# Altium schematic sheet pont en h.SchDoc("pont en h")
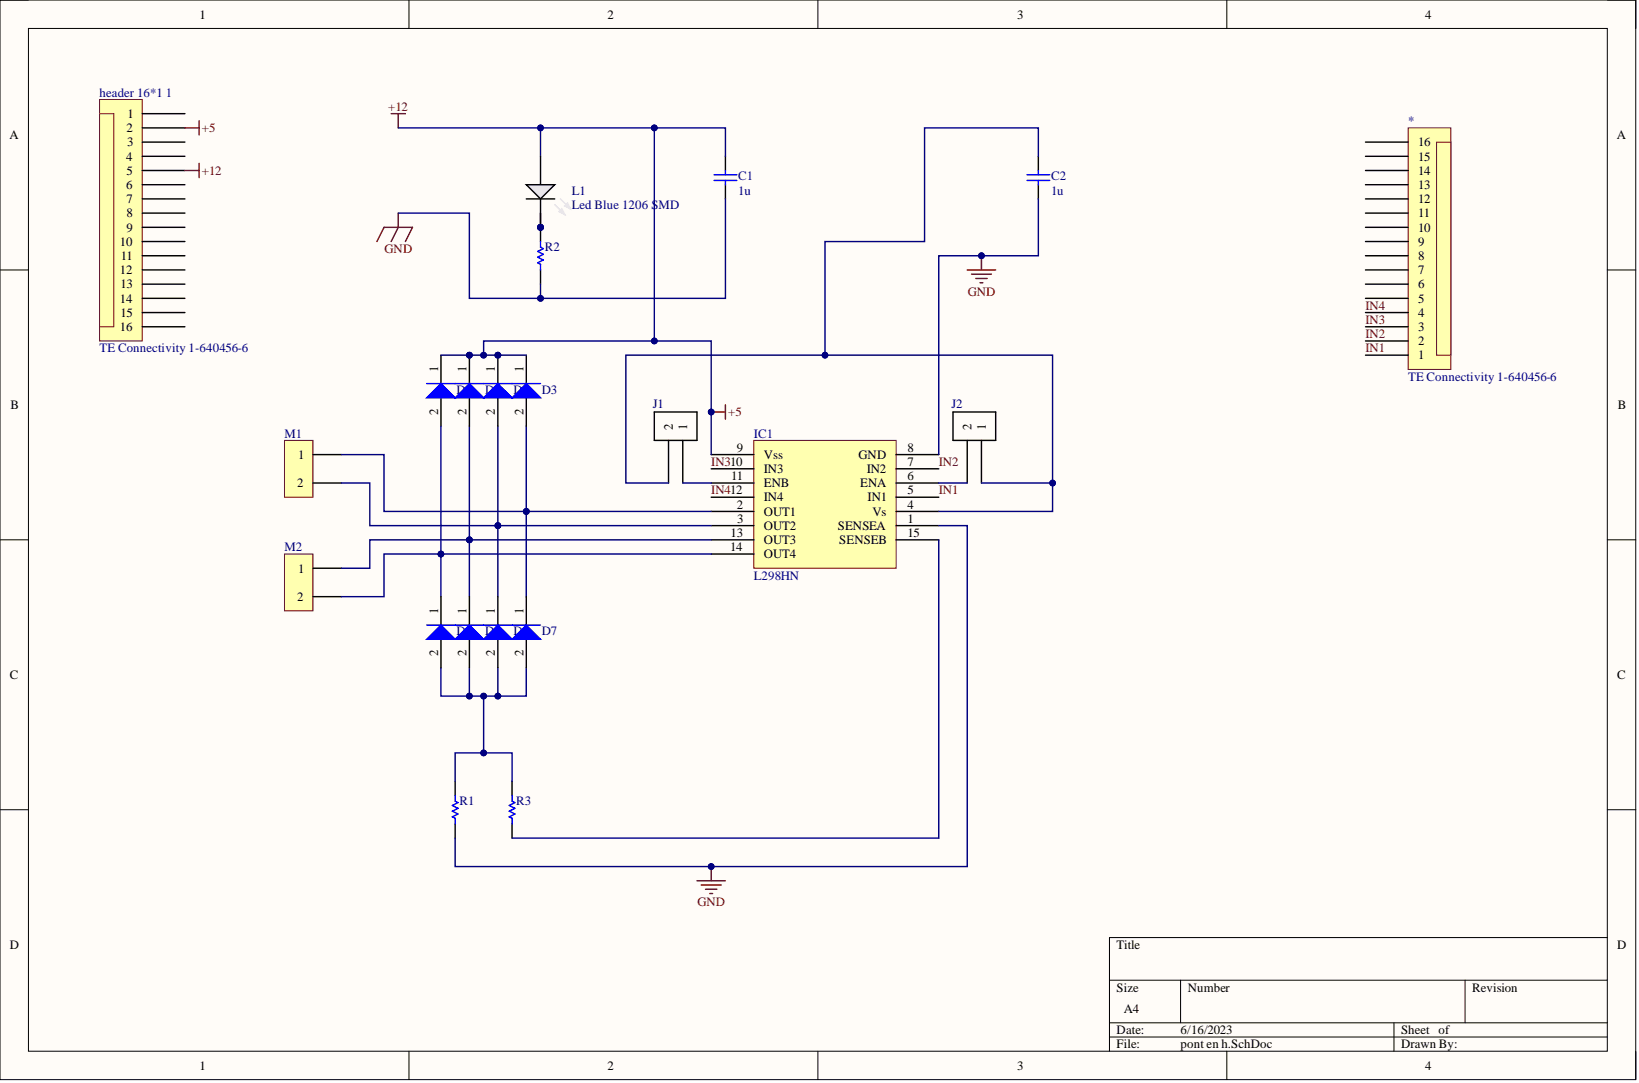
[diagram p0: pont en h.SchDoc]

## Parts
*  TE Connectivity 1-640456-6  [PCB-345877-1]
C1  1u
C2  1u
D1  (no value)  [PCB-93eesnz9fqft5tg3zumo-1]
D2  (no value)  [PCB-93eesnz9fqft5tg3zumo-1]
D3  (no value)  [PCB-93eesnz9fqft5tg3zumo-1]
D4  (no value)  [PCB-93eesnz9fqft5tg3zumo-1]
D5  (no value)  [PCB-93eesnz9fqft5tg3zumo-1]
D6  (no value)  [PCB-93eesnz9fqft5tg3zumo-1]
D7  (no value)  [PCB-93eesnz9fqft5tg3zumo-1]
D9  (no value)  [PCB-93eesnz9fqft5tg3zumo-1]
header_16*1_1  TE Connectivity 1-640456-6  Manufacturer Part Number: 1-640456-6  [PCB-345877-1]
IC1  L298HN
J1  (no value)  [PCB-psmpzu51py3p54h2h388-1]
J2  (no value)  [PCB-psmpzu51py3p54h2h388-1]
L1  Led Blue 1206 SMD  [PCB-dyudieb3kox3j5j1lvp2-1]
M1  (no value)  [PCB-aquf9io8cfjuczgbngpx-1]
M2  (no value)  [PCB-aquf9io8cfjuczgbngpx-1]
R1  (no value)
R2  (no value)
R3  (no value)

## Nets
+5: C1.2 D1.1 D2.1 D3.1 D9.1 header_16*1_1.2 header_16*1_1.5 IC1.9(Vss) L1.1
GND: C1.1 C2.1 IC1.1(SENSEA) IC1.8(GND) R1.1 R2.1
IN1: *.1 IC1.5(IN1)
IN2: *.2 IC1.7(IN2)
IN3: *.3 IC1.10(IN3)
IN4: *.4 IC1.12(IN4)
NetC2_2: C2.2 IC1.4(Vs) J1.2 J2.1
NetD1_2: D1.2 D5.1 IC1.13(OUT3) M2.1
NetD2_2: D2.2 D6.1 IC1.3(OUT2) M1.2
NetD3_2: D3.2 D7.1 IC1.2(OUT1) M1.1
NetD4_1: D4.1 D9.2 IC1.14(OUT4) M2.2
NetD4_2: D4.2 D5.2 D6.2 D7.2 R1.2 R3.2
NetIC1_6: IC1.6(ENA) J2.2
NetIC1_11: IC1.11(ENB) J1.1
NetIC1_15: IC1.15(SENSEB) R3.1
NetL1_2: L1.2 R2.2

Not connected: * 5 6 7 8 9 10 11 12 13 14 15 16; header_16*1_1 1 3 4 6 7 8 9 10 11 12 13 14 15 16

## Library data of the parts
* header_16*1_1 = CMP-5cb5977adcc98372-4: Header, Friction Lock; MTA-100; 16; 250 VAC; Polyester; Tin; Copper Alloy; Tin; ELV Compliant: Compliant; Gender: Male; Lead-Free Status: Lead Free; Mounting Angle: 180 °; Number of Contacts: 16; Pin Pitch: 2.54 mm; Shrouded: No; Voltage Rating (AC): 250 V; Color: White; Component Kind: Standard; Contact Material: Copper Alloy; Contact Plating: Tin; Flammability Rating: UL 94 V-0; Housing Color: White; Housing Material: Polyester; Library Name: My Personal Space; Lifecycle Status: Active; Mounting Style: Through Hole, Vertical; Number of Positions: 16; Number of Rows: 1; Octopart URL: https://octopart.com/1-640456-6-te+connectivity-46507290; OctopartID: 5cb5977adcc98372; Operating Temperature: 105 °C (max); Orientation: Vertical; Packaging: Bag; REACH SVHC Compliance: No SVHC; RoHS: Compliant; Size-Height: 7.87 mm; Size-Length: 40.6 mm; Size-Width: 5.71 mm; Voltage Rating (DC): 250 V
  *: Manufacturer Part Number: 1-640456-6
C1 C2 = CMP-8752282-2: Cap Ceramic 470pF 50V C0G 5% SMD 1206 125C Plastic T/R; Manufacturer Part Number: C1206C471J5GACTU; Capacitance: 4.7e-10 F; Lead-Free Status: Lead Free; Voltage Rating (DC): 50.0 V; Capacitance Tolerance: ±5%; Case/Package: 1206; Component Kind: Standard; Dielectric Material: Ceramic; Library Name: My Personal Space; Mounting Style: Surface Mount; Octopart Url: https://octopart.com/c1206c471j5gac7800-kemet-3915253; OctopartID: 8a66435712f555a1; RoHS: Compliant
D1-D7 D9 = CMP-1021910-11: Diode Small Signal Switching 100V 0.15A 2-Pin Case 1206(3216Metric) T/R; Manufacturer Part Number: CD1206-S01575; Case/Package (SI): 3216; Forward Voltage: 1.00 V; Case/Package: 1206; Component Kind: Standard; Library Name: My Personal Space; Mounting Style: Surface Mount; Number of Pins: 2; Octopart URL: https://octopart.com/cd1206-s01575-bourns-709948; OctopartID: d7cc1ecc2796080b; Operating Temperature: -55.0 °C to 125 °C; Packaging: Reel; REACH SVHC Compliance: No SVHC; RoHS: Compliant
IC1 = CMP-7f8fb6854c1aa048-2: PMIC - gate/half-bridge gate drivers STMicroelectronics L298HN Inductive Bipolar Multiwatt 15; Component Kind: Standard; Library Name: My Personal Space
J1 J2 = CMP-2811e342b2f0f7d6-11: AMPMODU Mod II Series, 2.54mm Pitch 2Way1 Row Straight PCB Header, Solder Term, 3A; Manufacturer Part Number: 87224-2; ELV Compliant: Compliant; Gender: Male; Lead-Free Status: Lead Free; Mounting Angle: 180 °; Number of Contacts: 2; Color: Black; Component Kind: Standard; Contact Material: Phosphor Bronze; Contact Plating: Gold, Gold Flash over Nickel; Flammability Rating: UL 94 V-0; Housing Color: Black; Housing Material: Thermoplastic; Library Name: My Personal Space; Lifecycle Status: Active; Mounting Style: PCB; Number of Positions: 2; Number of Rows: 1; Octopart URL: http://octopart.com/87224-2-te+connectivity+%2F+amp-39436167; OctopartID: 2811e342b2f0f7d6; Orientation: Vertical; Packaging: Bulk; Pin Pitch: 2.54 mm; RoHS: Compliant; Size-Length: 4.67 mm
L1 = CMP-0283cbd1fd8add44-10: High CRI LED; Color: 2700K; Case/Package: 5730; Component Kind: Standard; Library Name: My Personal Space; Number of Pins: 2; Octopart URL: https://cdn.shopify.com/s/files/1/0344/6401/files/YJWJ005-1.1_YJ-VTC-5730-G01.pdf?7088009637272241372; OctopartID: 0283cbd1fd8add44; Size-Height: 0.80mm; Size-Length: 6.80mm; Size-Width: 3.20mm
M1 M2 = CMP-bd257497f5ac62e2-1: 2 Position 3.5 mm Through Hole Straight Angle Header Plug with Closed End; Manufacturer Part Number: 734-132; Gender: Male; Lead-Free Status: Lead Free; Component Kind: Standard; Contact Plating: Tin; Flammability Rating: UL 94 V-0; Housing Color: Gray; Library Name: My Personal Space; Octopart URL: http://octopart.com/734-132-wago-12086767; OctopartID: bd257497f5ac62e2; RoHS: Compliant
R1-R3 = CMP-2823339-2: Res Thick Film 1206 1M Ohm 1% 1/4W ±100ppm/C Molded SMD Punched Paper T/R; Manufacturer Part Number: RK73H2BTTD1004F; Case/Package (SI): 3216; Lead-Free Status: Lead Free; Power Rating: 0.25 W; Resistance: 1000000.0 Ω; Temperature Coefficient: 100.0 ppm/°C; Voltage Rating (DC): 200.0 V; Case/Package: 1206; Component Kind: Standard; Library Name: My Personal Space; Mounting Style: Surface Mount; Octopart Url: https://octopart.com/rk73h2bttd1004f-koa+speer-2168503; OctopartID: 7040b96b4391af87; Resistance Tolerance: ±1%; RoHS: Compliant; Size-Length: 0.0032 m; Size-Width: 0.0016 m
